# Altium schematic sheet Buttons.SchDoc
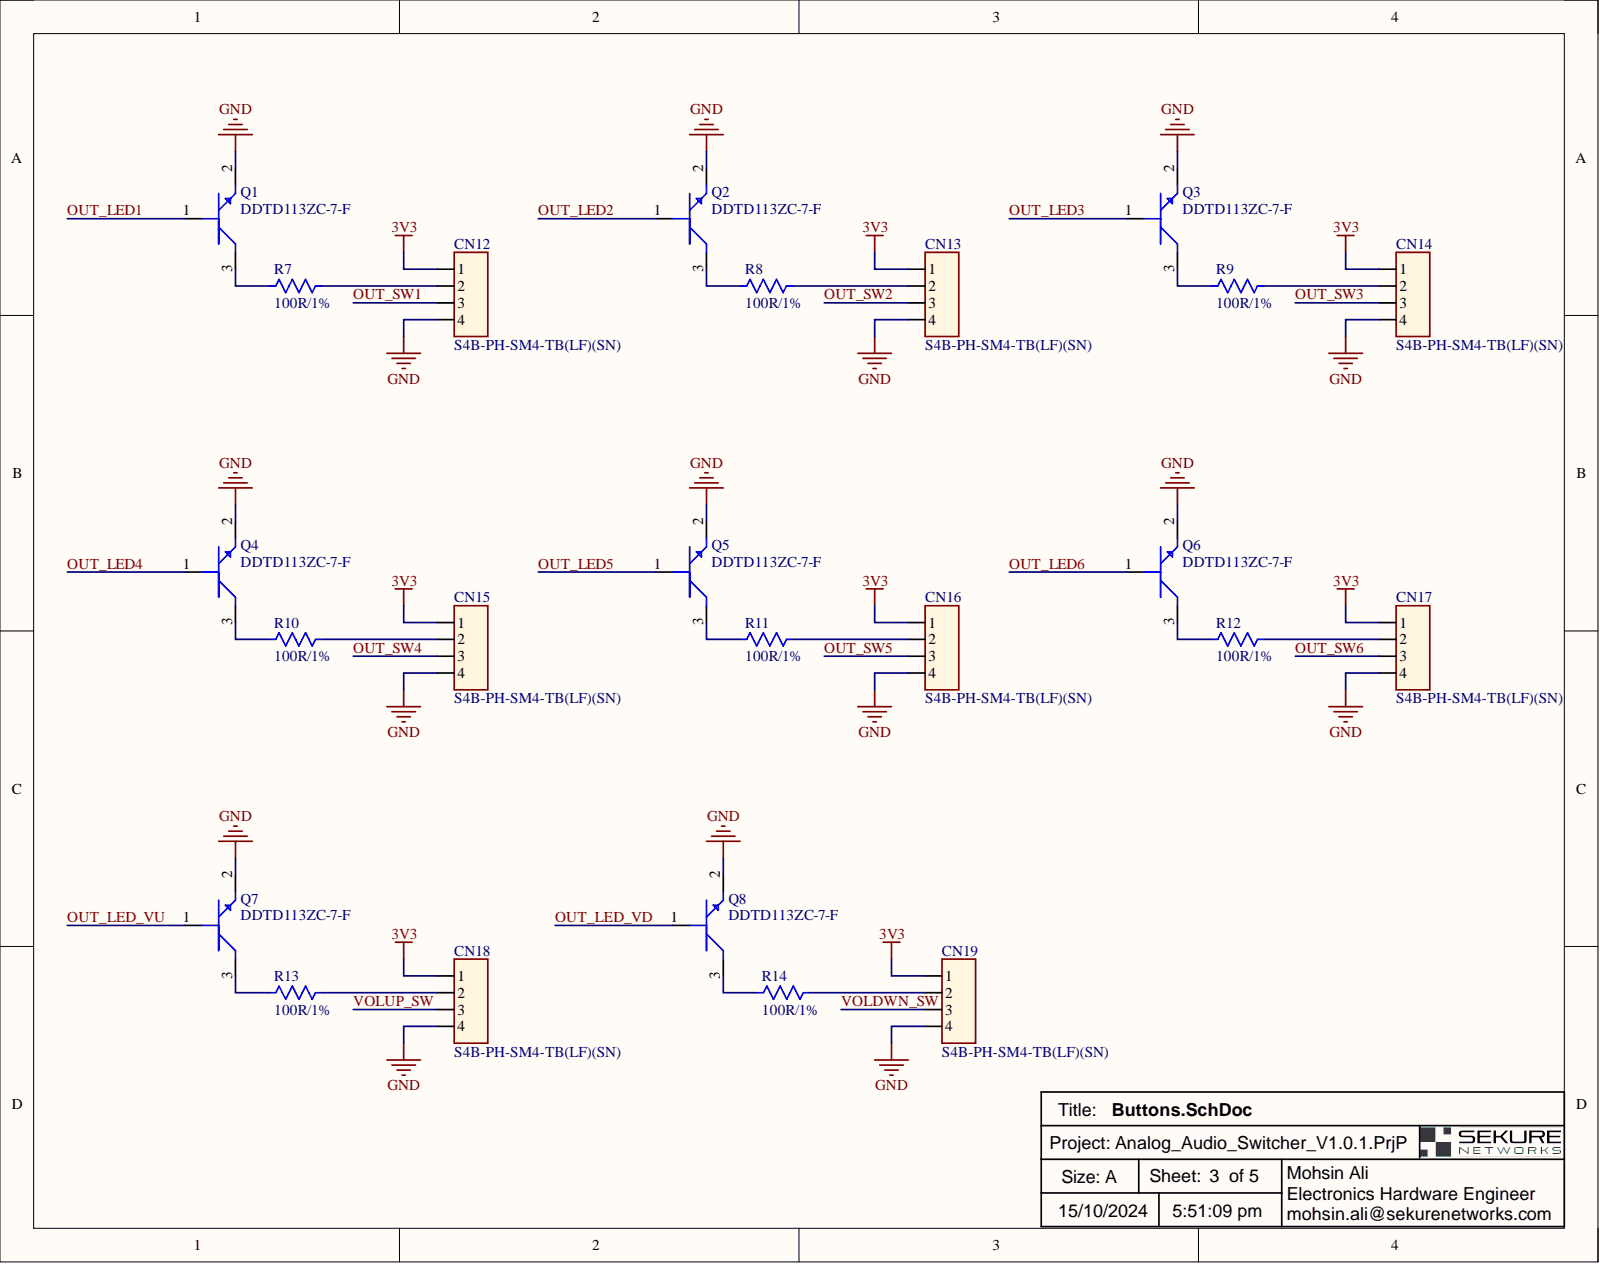
[diagram p0: Buttons.SchDoc]

## Parts
CN12  value S4B-PH-SM4-TB(LF)(SN)  [S4B-PH-SM4-TB(LF)(SN)]  (hidden comment: Connector)
CN13  value S4B-PH-SM4-TB(LF)(SN)  [S4B-PH-SM4-TB(LF)(SN)]  (hidden comment: Connector)
CN14  value S4B-PH-SM4-TB(LF)(SN)  [S4B-PH-SM4-TB(LF)(SN)]  (hidden comment: Connector)
CN15  value S4B-PH-SM4-TB(LF)(SN)  [S4B-PH-SM4-TB(LF)(SN)]  (hidden comment: Connector)
CN16  value S4B-PH-SM4-TB(LF)(SN)  [S4B-PH-SM4-TB(LF)(SN)]  (hidden comment: Connector)
CN17  value S4B-PH-SM4-TB(LF)(SN)  [S4B-PH-SM4-TB(LF)(SN)]  (hidden comment: Connector)
CN18  value S4B-PH-SM4-TB(LF)(SN)  [S4B-PH-SM4-TB(LF)(SN)]  (hidden comment: Connector)
CN19  value S4B-PH-SM4-TB(LF)(SN)  [S4B-PH-SM4-TB(LF)(SN)]  (hidden comment: Connector)
Q1  value DDTD113ZC-7-F  [SOT23]  (hidden comment: Transistor)
Q2  value DDTD113ZC-7-F  [SOT23]  (hidden comment: Transistor)
Q3  value DDTD113ZC-7-F  [SOT23]  (hidden comment: Transistor)
Q4  value DDTD113ZC-7-F  [SOT23]  (hidden comment: Transistor)
Q5  value DDTD113ZC-7-F  [SOT23]  (hidden comment: Transistor)
Q6  value DDTD113ZC-7-F  [SOT23]  (hidden comment: Transistor)
Q7  value DDTD113ZC-7-F  [SOT23]  (hidden comment: Transistor)
Q8  value DDTD113ZC-7-F  [SOT23]  (hidden comment: Transistor)
R7  value 100R/1%  [RES 0603]  (hidden comment: Resistor)
R8  value 100R/1%  [RES 0603]  (hidden comment: Resistor)
R9  value 100R/1%  [RES 0603]  (hidden comment: Resistor)
R10  value 100R/1%  [RES 0603]  (hidden comment: Resistor)
R11  value 100R/1%  [RES 0603]  (hidden comment: Resistor)
R12  value 100R/1%  [RES 0603]  (hidden comment: Resistor)
R13  value 100R/1%  [RES 0603]  (hidden comment: Resistor)
R14  value 100R/1%  [RES 0603]  (hidden comment: Resistor)

## Nets
3V3: CN12.1 CN13.1 CN14.1 CN15.1 CN16.1 CN17.1 CN18.1 CN19.1
GND: CN12.4 CN13.4 CN14.4 CN15.4 CN16.4 CN17.4 CN18.4 CN19.4 Q1.2 Q2.2 Q3.2 Q4.2 Q5.2 Q6.2 Q7.2 Q8.2
OUT_LED1: Q1.1
OUT_LED2: Q2.1
OUT_LED3: Q3.1
OUT_LED4: Q4.1
OUT_LED5: Q5.1
OUT_LED6: Q6.1
OUT_LED_VD: Q8.1
OUT_LED_VU: Q7.1
OUT_SW1: CN12.3
OUT_SW2: CN13.3
OUT_SW3: CN14.3
OUT_SW4: CN15.3
OUT_SW5: CN16.3
OUT_SW6: CN17.3
VOLDWN_SW: CN19.3
VOLUP_SW: CN18.3
NetCN12_2: CN12.2 R7.2
NetCN13_2: CN13.2 R8.2
NetCN14_2: CN14.2 R9.2
NetCN15_2: CN15.2 R10.2
NetCN16_2: CN16.2 R11.2
NetCN17_2: CN17.2 R12.2
NetCN18_2: CN18.2 R13.2
NetCN19_2: CN19.2 R14.2
NetQ1_3: Q1.3 R7.1
NetQ2_3: Q2.3 R8.1
NetQ3_3: Q3.3 R9.1
NetQ4_3: Q4.3 R10.1
NetQ5_3: Q5.3 R11.1
NetQ6_3: Q6.3 R12.1
NetQ7_3: Q7.3 R13.1
NetQ8_3: Q8.3 R14.1

## Library data of the parts
CN12-CN19 = S4B-PH-SM4-TB(LF)(SN): Connector Header, PH Series, 4 Position, Side Entry, 2.00mm, Solder, Surface Mount, Natural.; Manufacturer: JST; Category: Connectors; Component Kind: Standard; ComponentLink1Description: https://datasheet.ciiva.com/11746/0900766b80df0d9d-11746552.pdf?src-supplier=RSComponents; ComponentLink1URL: https://datasheet.ciiva.com/11746/0900766b80df0d9d-11746552.pdf?src-supplier=RSComponents; ComponentLink2Description: https://datasheet.ciiva.com/26894/getdatasheetpartid-639990-26894620.pdf?src-supplier=Verical; ComponentLink2URL: https://datasheet.ciiva.com/26894/getdatasheetpartid-639990-26894620.pdf?src-supplier=Verical; ComponentLink3Description: https://datasheet.ciiva.com/16376/eph-16376783.pdf?src-supplier=Future+Electronics; ComponentLink3URL: https://datasheet.ciiva.com/16376/eph-16376783.pdf?src-supplier=Future+Electronics; ComponentLink4Description: https://datasheet.ciiva.com/8052/1699381-8052234.pdf?src-supplier=Element14; ComponentLink4URL: https://datasheet.ciiva.com/8052/1699381-8052234.pdf?src-supplier=Element14; ComponentLink5Description: https://datasheet.ciiva.com/712/eph-712578.pdf?src-supplier=Digi-Key; ComponentLink5URL: https://datasheet.ciiva.com/712/eph-712578.pdf?src-supplier=Digi-Key; ComponentLink6Description: https://datasheet.ciiva.com/712/eph-712579.pdf?src-supplier=Digi-Key; ComponentLink6URL: https://datasheet.ciiva.com/712/eph-712579.pdf?src-supplier=Digi-Key; ComponentLink7Description: https://datasheet.ciiva.com/730/eph-730675.pdf?src-supplier=Digi-Key; ComponentLink7URL: https://datasheet.ciiva.com/730/eph-730675.pdf?src-supplier=Digi-Key; DigiKey: 455-1751-1-ND; Library Name: Connectors.IntLib; P/N: S4B-PH-SM4-TB(LF)(SN); Pricing 1: 1=0.74000, 10=0.64300, 100=0.53020, 500=0.46108 (USD); RoHS: Yes; Stock 1: 33878; Supplier 1: Digi-Key
Q1-Q8 = DDTD113ZC-7-F: DDTDxxxxC Series NPN 50 V 500 mA 1 kO/10 kO Pre-Baised Transistor - SOT-23; Manufacturer: Diodes Zetex; Category: BJTs; Component Kind: Standard; ComponentLink1Description: https://datasheet.ciiva.com/15139/getdatasheetpartid-323116-15139824.pdf?src-supplier=Verical; ComponentLink1URL: https://datasheet.ciiva.com/15139/getdatasheetpartid-323116-15139824.pdf?src-supplier=Verical; DigiKey: DDTD113ZC-7FDICT-ND; Library Name: Transistor.IntLib; P/N: DDTD113ZC-7-F; Pricing: 1=0.17, 10=0.117, 100=0.0784, 500=0.05978, 1000=0.0535 (USD); RoHS: Yes; Stock: 756266; Supplier: Digikey
R7-R14 = CRCW0603100RFKEA: RES SMD 100 OHM 1% 1/10W 0603; Manufacturer: Vishay Dale; Max Power Dissipation: 100mW; Resistance: 100R; Temperature Coefficient: 100ppm/°C; Voltage Rating: 75V; Case Code (Imperial): 0603; Case Code (Metric): 1608; Case/Package: 0603; Component Kind: Standard; ComponentLink1Description: https://datasheet.ciiva.com/16941/dcrcwe3-16941318.pdf?src-supplier=Avnet+Europe; ComponentLink1URL: https://datasheet.ciiva.com/16941/dcrcwe3-16941318.pdf?src-supplier=Avnet+Europe; ComponentLink2Description: https://datasheet.ciiva.com/26316/fld-crcw-e3-data-en-26316566.pdf?src-supplier=Distrelec; ComponentLink2URL: https://datasheet.ciiva.com/26316/fld-crcw-e3-data-en-26316566.pdf?src-supplier=Distrelec; ComponentLink3Description: https://datasheet.ciiva.com/2465/dcrcwe3-2465543.pdf?src-supplier=Digi-Key; ComponentLink3URL: https://datasheet.ciiva.com/2465/dcrcwe3-2465543.pdf?src-supplier=Digi-Key; ComponentLink4Description: https://datasheet.ciiva.com/2465/dcrcwe3-2465544.pdf?src-supplier=Digi-Key; ComponentLink4URL: https://datasheet.ciiva.com/2465/dcrcwe3-2465544.pdf?src-supplier=Digi-Key; ComponentLink5Description: https://datasheet.ciiva.com/2465/dcrcwe3-2465545.pdf?src-supplier=Digi-Key; ComponentLink5URL: https://datasheet.ciiva.com/2465/dcrcwe3-2465545.pdf?src-supplier=Digi-Key; ComponentLink6Description: https://datasheet.ciiva.com/22673/1697337-22673066.pdf?src-supplier=Element14; ComponentLink6URL: https://datasheet.ciiva.com/22673/1697337-22673066.pdf?src-supplier=Element14; ComponentLink7Description: https://datasheet.ciiva.com/16787/70201119-16787964.pdf?src-supplier=Allied+Electronics; ComponentLink7URL: https://datasheet.ciiva.com/16787/70201119-16787964.pdf?src-supplier=Allied+Electronics; ComponentLink8Description: https://datasheet.ciiva.com/5550/dcrcwe3-109170-5550286.pdf?src-supplier=Mouser; ComponentLink8URL: https://datasheet.ciiva.com/5550/dcrcwe3-109170-5550286.pdf?src-supplier=Mouser; ComponentLink9Description: https://datasheet.ciiva.com/16199/dcrcwe3-16199102.pdf?src-supplier=Future+Electronics; ComponentLink9URL: https://datasheet.ciiva.com/16199/dcrcwe3-16199102.pdf?src-supplier=Future+Electronics; ComponentLink10Description: https://datasheet.ciiva.com/16721/70201119-16721486.pdf?src-supplier=Allied+Electronics; ComponentLink10URL: https://datasheet.ciiva.com/16721/70201119-16721486.pdf?src-supplier=Allied+Electronics; ComponentLink11Description: https://datasheet.ciiva.com/22666/1720486-22666207.pdf?src-supplier=Element14; ComponentLink11URL: https://datasheet.ciiva.com/22666/1720486-22666207.pdf?src-supplier=Element14; Composition: Thick Film; Contact Plating: Tin; Depth: 0.85mm; DigiKey: 541-100HCT-ND; Features: Automotive AEC-Q200; Height: 0.02inch; Lead Free: Lead Free; Length: 1.55mm; Library Name: Resistors.IntLib; Max Operating Temperature: 155°C; Military Standard: Not; Min Operating Temperature: -55°C; Mount: Surface Mount; Number of Pins: 2; Number of Terminations: 2; P/N: CRCW0603100RFKEA; Package Quantity: 10; Packaging: Tape and Reel; Power Rating: 100mW; Pricing 1: 1=0.10000, 10=0.03400, 100=0.01390, 1000=0.00623, 2500=0.00540 (USD); Radiation Hardening: No; REACH SVHC: Unknown; RoHS: Yes; Stock 1: 512087; Termination: SMD/SMT; Tolerance: 1%; Voltage Rating (DC): 75V; Weight: 7.1E-05oz; Width: 0.033inch
